# Altium schematic sheet Motor_Driver.SchDoc(Motor_Driver)
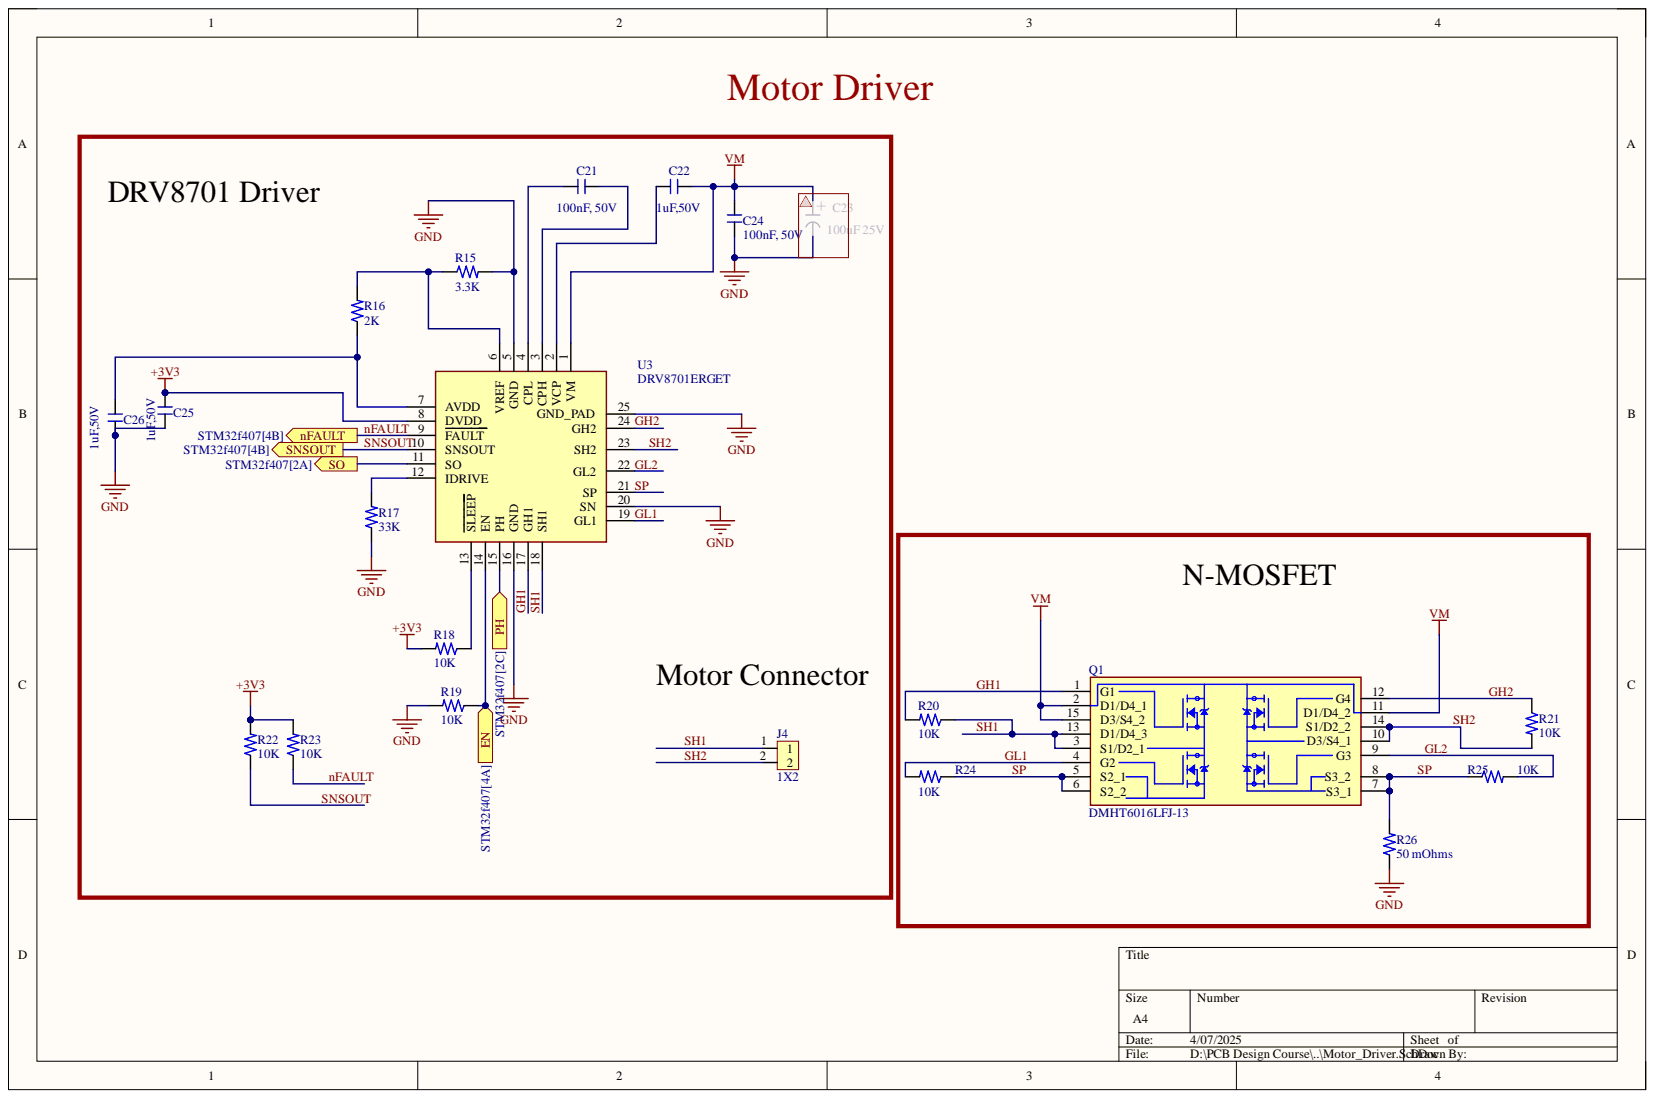
[diagram p0: Motor_Driver.SchDoc]

## Parts
C21  100nF, 50V  Voltage: 50V  [Capacitor_0805]
C22  1uF,50V  [Capacitor_0805]
C24  100nF, 50V  [Capacitor_0805]
C25  1uF,50V  [Capacitor_0805]
C26  1uF,50V  [Capacitor_0805]
J4  1X2  [1X2_2.53_JST]
Q1  DMHT6016LFJ-13  [V-DFN5045-12]
R15  3.3K  [Resistor_0603]
R16  2K  [Resistor_0603]
R17  33K  [Resistor_0805]
R18  10K  [Resistor_0603]
R19  10K  [Resistor_0603]
R20  10K  [Resistor_0603]
R21  10K  [Resistor_0603]
R22  10K  [Resistor_0603]
R23  10K  [Resistor_0603]
R24  10K  [Resistor_0603]
R25  10K  [Resistor_0603]
R26  50 mOhms  [Resistor_2512]
U3  DRV8701ERGET  [24_VQFN_4X4]

## Ports
EN, nFAULT, PH, SNSOUT, SO

## Nets
+3V3: C25.2 R18.2 R22.2 R23.2 U3.8(DVDD)
GND: C24.1 C25.1 C26.1 R15.2 R17.1 R19.2 R26.1 U3.5(GND) U3.16(GND) U3.20(SN) U3.25(GND_PAD)
VM: C22.2 C24.2 Q1.2(D1/D4_1) Q1.11(D1/D4_2) Q1.15(D3/S4_2) U3.1(VM)
EN: R19.1 U3.14(EN) ; port EN
GH1: Q1.1(G1) R20.2 U3.17(GH1)
GH2: Q1.12(G4) R21.1 U3.24(GH2)
GL1: Q1.4(G2) R24.2 U3.19(GL1)
GL2: Q1.9(G3) R25.2 U3.22(GL2)
nFAULT: R23.1 U3.9(FAULT) ; port nFAULT
PH: U3.15(PH) ; port PH
SH1: J4.1 Q1.3(S1/D2_1) Q1.13(D1/D4_3) R20.1 U3.18(SH1)
SH2: J4.2 Q1.10(D3/S4_1) Q1.14(S1/D2_2) R21.2 U3.23(SH2)
SNSOUT: R22.1 U3.10(SNSOUT) ; port SNSOUT
SO: U3.11(SO) ; port SO
SP: Q1.5(S2_1) Q1.6(S2_2) Q1.7(S3_1) Q1.8(S3_2) R24.1 R25.1 R26.2 U3.21(SP)
NetC21_1: C21.1 U3.4(CPL)
NetC21_2: C21.2 U3.3(CPH)
NetC22_1: C22.1 U3.2(VCP)
NetC26_2: C26.2 R16.1 U3.7(AVDD)
NetR15_1: R15.1 R16.2 U3.6(VREF)
NetR17_2: R17.2 U3.12(IDRIVE)
NetR18_1: R18.1 U3.13(SLEEP)

## Library data of the parts
C21 C24 = Cap_100nF_0805: Multilayer Ceramic Capacitor, 0.1 uF, 50 V, ï¿½ 10%, X7R, 0805 [2012 Metric]; Manufacturer: Samsung; Manufacturer Part Number: CL21B104KBCNNNC; Case Code (Imperial): 0805; Case Code (Metric): 2012; Case/Package: 0805; Category: Ceramic Capacitors; Component Kind: Standard; ComponentLink1Description: https://datasheet.ciiva.com/25710/cl21b104kbcnnnc-25710182.pdf?src-supplier=Digikey; ComponentLink1URL: https://datasheet.ciiva.com/25710/cl21b104kbcnnnc-25710182.pdf?src-supplier=Digikey; ComponentLink2Description: https://datasheet.ciiva.com/29199/454152-da-01-en-vs-kondensator-0805-29199814.pdf?src-supplier=Conrad; ComponentLink2URL: https://datasheet.ciiva.com/29199/454152-da-01-en-vs-kondensator-0805-29199814.pdf?src-supplier=Conrad; Construction: Flat; Depth: 1.25mm; Dielectric: X7R; Height: 0.85mm; Lead Free: 5697LeadFree; Length: 2mm; Material: Ceramic; Max Operating Temperature: 125°C; Min Operating Temperature: -55°C; Mounting Technology: Surface Mount; Packaging: Tape and Reel; Pricing: 1=0,08, 10=0,012, 100=0,0104, 1000=0,008 (USD); Radiation Hardening: No; RoHS: Yes; RoHS Compliant: Yes; Series: CL; Stock: 674609; Supplier: Digikey; Supplier Part Number: 1276-1003-1-ND; Thickness: 0.037inch; Tolerance: 10%; Value: 100nF; Voltage Rating: 50V; Voltage Rating (DC): 50V; Width: 0.049inch; Library Name: Schematics_Library_Hanif.SchLib
  C24: Voltage: 50V
C22 C25 C26 = Cap_1uF_0805: 0805 1 uF 50 V ±5 % Tolerance X7R Surface Mount Multilayer Ceramic Capacitor; Manufacturer: Yageo; Manufacturer Part Number: CC0805JKX7R9BB105; Dielectric: 1511DielectricType; Material: 4241ConductorMaterial; Category: Ceramic Capacitors; Component Kind: Standard; ComponentLink1Description: https://datasheet.octopart.com/CC0805JKX7R9BB105-Yageo-datasheet-137700082.pdf?src-supplier=Future+Electronics; ComponentLink1URL: https://datasheet.octopart.com/CC0805JKX7R9BB105-Yageo-datasheet-137700082.pdf?src-supplier=Future+Electronics; ComponentLink2Description: http://www.yageo.com/documents/recent/UPY-GPHC_X7R_6.3V-to-50V_18.pdf?src-supplier=Digikey; ComponentLink2URL: http://www.yageo.com/documents/recent/UPY-GPHC_X7R_6.3V-to-50V_18.pdf?src-supplier=Digikey; ComponentLink3Description: https://datasheet.octopart.com/CC0805JKX7R9BB105-Yageo-datasheet-151948686.pdf?src-supplier=Farnell; ComponentLink3URL: https://datasheet.octopart.com/CC0805JKX7R9BB105-Yageo-datasheet-151948686.pdf?src-supplier=Farnell; Halogen Free: 5694HalogenFree; Height: 1.45mm; Lead Free: 5697LeadFree; Pricing: 1=0,29, 10=0,168, 100=0,1077, 1000=0,07673 (USD); RoHS: Yes; Stock: 30160; Supplier: Digikey; Supplier Part Number: 311-3498-1-ND; Voltage Rating (DC): 50V; Library Name: Schematics_Library_Hanif.SchLib
J4 = CON_JST_1X2_2.54: Conn Shrouded Header HDR 2 POS 2.5mm Solder ST Thru-Hole Box; Manufacturer: JST; Manufacturer Part Number: B2B-XH-2(LF)(SN); Number of Contacts: 2NUMBER; Number of Positions: 2; Voltage Rating: 250V; Category: Headers and Wire Housings; Component Kind: Standard; Connector Type: Header; Contact Finish - Mating: TIN; Contact Material: Brass; Contact Shape: Square; Contact Type: Male Pin; Fastening Type: Detent; Flammability Rating: UL94 V-0; Insulation Color: Natural; Insulation Material: Nylon; Max Operating Temperature: 85°C; Min Operating Temperature: -25°C; Mounting Technology: Through Hole; Number of Rows: 1; Packaging: Bulk; Pricing: 1=0,2, 10=0,158, 50=0,131, 100=0,117, 1000=0,072, 10000=0,07 (USD); RoHS: Yes; Series: XH; Stock: 1704; Supplier: Mouser; Supplier Part Number: 306-B2B-XH-2LFSN; Termination: Press-Fit; Library Name: Schematics_Library_Hanif.SchLib
Q1 = IC_MOSFET_ARRAY_4N: Transistor MOSFET Array Quad N-CH 60V 14.8A 12-Pin VDFN T/R; Manufacturer: Diodes; FET Type: 4 N-Channel; Input Capacitance: 864pF; Rds On Max: 22mR; Category: MOSFETs; Component Kind: Standard; ComponentLink1Description: https://www.diodes.com/assets/Datasheets/DMHT6016LFJ.pdf?src-supplier=Digikey; ComponentLink1URL: https://www.diodes.com/assets/Datasheets/DMHT6016LFJ.pdf?src-supplier=Digikey; Continuous Drain Current (ID): 14.8A; Manufacturer Part Number: DMHT6016LFJ-13; Max Operating Temperature: 150°C; Min Operating Temperature: -55°C; Mounting Technology: Surface Mount; Packaging: Cut Tape; Pricing: 1=2,31, 10=1,485, 100=1,0129, 500=0,81076, 1000=0,74579 (USD); RoHS: Yes; Series: Automotive, AEC-Q101; Stock: 8228; Supplier: Digikey; Supplier Part Number: DMHT6016LFJ-13DICT-ND; Library Name: Schematics_Library_Hanif.SchLib
R15 = R_3.3K_0603: Res Thick Film 0603 3.3K Ohm 1% 0.1W(1/10W) ±100ppm/C Pad SMD T/R; Manufacturer: Walsin Technologies; Manufacturer Part Number: WR06X3301FTL; Power Rating: 100mW; Temperature Coefficient: 100ppm/°C; Value: 3.3kR; Voltage Rating: 75V; Case Code (Imperial): 0603; Case Code (Metric): 1608; Case/Package: 0603; Category: Chip SMD Resistors; Component Kind: Standard; ComponentLink1Description: http://www.farnell.com/datasheets/1960319.pdf?src-supplier=Farnell; ComponentLink1URL: http://www.farnell.com/datasheets/1960319.pdf?src-supplier=Farnell; ComponentLink2Description: https://datasheet.octopart.com/WR06X3301FTL-Walsin-Technologies-datasheet-48038251.pdf?src-supplier=Element14; ComponentLink2URL: https://datasheet.octopart.com/WR06X3301FTL-Walsin-Technologies-datasheet-48038251.pdf?src-supplier=Element14; ComponentLink3Description: http://www.farnell.com/datasheets/1960319.pdf?src-supplier=Element14; ComponentLink3URL: http://www.farnell.com/datasheets/1960319.pdf?src-supplier=Element14; ComponentLink4Description: https://datasheet.octopart.com/WR06X3301FTL-Walsin-Technologies-datasheet-32780790.pdf?src-supplier=Future+Electronics; ComponentLink4URL: https://datasheet.octopart.com/WR06X3301FTL-Walsin-Technologies-datasheet-32780790.pdf?src-supplier=Future+Electronics; Contact Material: 154ConductorMaterial; Lead Free: 5697LeadFree; Mounting Technology: Surface Mount; Packaging: Tape and Reel; Pricing: 1=0,1, 10=0,015, 50=0,0086, 100=0,0068, 500=0,00418, 1000=0,00345 (USD); Reach SVHC Compliant: Unknown; Resistance Tolerance: 1%; Resistor Type: Thick Film; RoHS: Yes; RoHS Compliant: Yes; Stock: 24599; Supplier: Digikey; Supplier Part Number: 1292-WR06X3301FTLCT-ND; Voltage Rating (DC): 75V; Library Name: Schematics_Library_Hanif.SchLib
R16 = R_2k_0603: Res Thin Film 0603 2K Ohm 1% 0.125W(1/8W) ±100ppm/C Sulfur Resistant Pad SMD T/R; Manufacturer: Stackpole Electronics; Manufacturer Part Number: RNCP0603FTD2K00; Max Power Dissipation: 125mW; Temperature Coefficient: 100ppm/°C; Value: 2kR; Voltage Rating: 150V; Case Code (Imperial): 0603; Case Code (Metric): 1608; Case/Package: 0603; Category: Chip SMD Resistors; Component Kind: Standard; ComponentLink1Description: https://www.seielect.com/catalog/SEI-Packaging.pdf?src-supplier=Digikey; ComponentLink1URL: https://www.seielect.com/catalog/SEI-Packaging.pdf?src-supplier=Digikey; Features: Moisture Resistant,Anti-Sulfur; Height: 0.02inch; Lead Free: Lead Free; Length: 0.059inch; Max Operating Temperature: 155°C; Min Operating Temperature: -55°C; Mounting Technology: Surface Mount; Number of Terminations: 2; Packaging: Cut Tape; Pricing: 1=0,1, 10=0,012, 50=0,0108, 100=0,0106, 500=0,00848 (USD); RoHS: Yes; RoHS Compliant: Yes; Series: RNCP; Stock: 64735; Supplier: Digikey; Supplier Part Number: RNCP0603FTD2K00CT-ND; Tolerance: 1%; Width: 0.031inch; Library Name: Schematics_Library_Hanif.SchLib
R17 = R_33K_0805: Res Thick Film 0805 33K Ohm 1% 0.125W(1/8W) ±100ppm/C Pad SMD T/R; Manufacturer: TE Connectivity; Manufacturer Part Number: CRG0805F33K; Max Power Dissipation: 125mW; Number of Resistors: 1; Operating Supply Voltage: 150V; Temperature Coefficient: 100ppm/°C; Value: 33kR; Case Code (Imperial): 0805; Case Code (Metric): 2012; Case/Package: 0805; Category: Chip SMD Resistors; Component Kind: Standard; ComponentLink1Description: https://datasheet.ciiva.com/2530/eng-cd-1623197-ba-2530138.pdf?src-supplier=Digikey; ComponentLink1URL: https://datasheet.ciiva.com/2530/eng-cd-1623197-ba-2530138.pdf?src-supplier=Digikey; ComponentLink2Description: https://datasheet.ciiva.com/11818/0900766b800a696e-11818279.pdf?src-supplier=Rs; ComponentLink2URL: https://datasheet.ciiva.com/11818/0900766b800a696e-11818279.pdf?src-supplier=Rs; ComponentLink3Description: https://datasheet.ciiva.com/5597/eng-ds-1773204-g-5597309.pdf?src-supplier=Mouser; ComponentLink3URL: https://datasheet.ciiva.com/5597/eng-ds-1773204-g-5597309.pdf?src-supplier=Mouser; ComponentLink4Description: https://datasheet.ciiva.com/16772/70063020-16772846.pdf?src-supplier=Allied+Electronics; ComponentLink4URL: https://datasheet.ciiva.com/16772/70063020-16772846.pdf?src-supplier=Allied+Electronics; Depth: 1.25mm; ELV: Compliant; Height: 0.55mm; Length: 2mm; Max Operating Temperature: 125°C; Military Standard: Not; Min Operating Temperature: -55°C; Mounting Technology: Surface Mount; Number of Terminations: 2; Packaging: Tape and Reel; Power Rating: 125mW; Pricing: 1=0,1, 10=0,037, 100=0,019, 1000=0,01105, 2500=0,00928 (USD); Resistor Type: Thick Film; RoHS: Yes; RoHS Compliant: Yes; Series: CRG; Stock: 31419; Supplier: Digikey; Supplier Part Number: A126362CT-ND; Technology: THICK FILM; Termination: Solder; Tolerance: 1%; Voltage Rating: 150V; Voltage Rating (DC): 150V; Width: 1.25mm; Library Name: Schematics_Library_Hanif.SchLib
R18-R25 = R_10K_0603: SMD Chip Resistor, 10 kOhm, ï¿½ 1%, 100 mW, 0603 [1608 Metric], Thick Film, General Purpose; Manufacturer: Yageo; Manufacturer Part Number: RC0603FR-0710KL; Max Power Dissipation: 100mW; Temperature Coefficient: 100ppm/°C; Value: 10kR; Voltage Rating: 75V; Voltage Rating (DC): 50V; Case Code (Imperial): 0603; Case Code (Metric): 1608; Case/Package: 0603; Category: Chip SMD Resistors; Component Kind: Standard; ComponentLink1Description: https://datasheet.ciiva.com/7660/38590-7660789.pdf?src-supplier=Element14; ComponentLink1URL: https://datasheet.ciiva.com/7660/38590-7660789.pdf?src-supplier=Element14; ComponentLink2Description: https://datasheet.ciiva.com/7983/443971-7983596.pdf?src-supplier=Element14; ComponentLink2URL: https://datasheet.ciiva.com/7983/443971-7983596.pdf?src-supplier=Element14; ComponentLink3Description: https://datasheet.ciiva.com/22747/443971-22747519.pdf?src-supplier=Element14; ComponentLink3URL: https://datasheet.ciiva.com/22747/443971-22747519.pdf?src-supplier=Element14; ComponentLink4Description: https://datasheet.ciiva.com/46440/1868787-46440891.pdf?src-supplier=Element14; ComponentLink4URL: https://datasheet.ciiva.com/46440/1868787-46440891.pdf?src-supplier=Element14; ComponentLink5Description: https://datasheet.ciiva.com/7746/1738695-7746239.pdf?src-supplier=Element14; ComponentLink5URL: https://datasheet.ciiva.com/7746/1738695-7746239.pdf?src-supplier=Element14; ComponentLink6Description: https://datasheet.ciiva.com/15189/getdatasheetpartid-409289-15189591.pdf?src-supplier=Verical; ComponentLink6URL: https://datasheet.ciiva.com/15189/getdatasheetpartid-409289-15189591.pdf?src-supplier=Verical; Construction: Rectangular; Depth: 0.8mm; Features: Moisture Resistant; Height: 0.45mm; Lead Free: 5697LeadFree; Lead Pitch: 0.3mm; Length: 1.6mm; Max Operating Temperature: 155°C; Military Standard: Not; Min Operating Temperature: -55°C; Number of Terminations: 2; Packaging: Tape and Reel; Pins: 2; Power Rating: 100mW; Pricing: 1=0,1, 10=0,009, 100=0,0071, 1000=0,00612 (USD); Reach SVHC Compliant: No; Resistance Tolerance: 1%; Resistor Type: Thick Film; RoHS: Yes; RoHS Compliant: Yes; Series: RC; Stock: 2808060; Supplier: Digikey; Supplier Part Number: 311-10.0KHRCT-ND; Termination: SMD/SMT; Tolerance: 1%; Weight: 7.1E-05oz; Width: 0.8mm; Library Name: Schematics_Library_Hanif.SchLib
R26 = R_50mOhms_2012: Res Current Sense Metal Film 2512 50m Ohm 1% 2W ±100ppm/°C Molded Emboss T/R; Manufacturer: Yageo; Manufacturer Part Number: PA2512FKF7W0R05E; Value: 50mR; Case Code (Imperial): 2512; Case Code (Metric): 6432; Case/Package: 2512; Category: Chip SMD Resistors; Component Kind: Standard; Features: Moisture Resistant,Automotive AEC-Q200; Height: 880um; Max Operating Temperature: 170°C; Max Power Dissipation: 2W; Min Operating Temperature: -55°C; Number of Terminations: 2; Packaging: Cut Tape; Power Rating: 2W; Pricing: 1=0,8, 10=0,521, 25=0,444, 50=0,3958, 100=0,3549, 250=0,31004, 500=0,28194, 1000=0,25807 (USD); Ratings: AEC-Q200; RoHS: Yes; RoHS Compliant: Yes; Series: PA_E; Stock: 10433; Supplier: Digikey; Supplier Part Number: YAG6100CT-ND; Temperature Coefficient: 100ppm/°C; Tolerance: 1%; Library Name: Schematics_Library_Hanif.SchLib
U3 = IC_Motor_DriverDRV8701ERGET: 4.5V to 60V, 2A Step-Down Power Module in QFN Package 43-B3QFN -40 to 105; Manufacturer: Texas Instruments; Category: Integrated Circuits (ICs); Component Kind: Standard; ComponentLink1Description: http://www.ti.com/lit/ds/symlink/drv8701.pdf?src-supplier=Digikey; ComponentLink1URL: http://www.ti.com/lit/ds/symlink/drv8701.pdf?src-supplier=Digikey; ComponentLink2Description: http://www.ti.com/general/docs/lit/getliterature.tsp?genericPartNumber=DRV8701&&fileType=pdf&src-supplier=Avnet; ComponentLink2URL: http://www.ti.com/general/docs/lit/getliterature.tsp?genericPartNumber=DRV8701&&fileType=pdf&src-supplier=Avnet; Height: 1mm; Lead Free: 5697LeadFree; Length: 4mm; Manufacturer Part Number: DRV8701ERGET; Pins: 24NUMBER; Pricing: 1=2,56, 10=1,91, 50=1,75, 100=1,57, 1000=1,35, 10000=1,28 (USD); RoHS: Yes; Stock: 1460; Supplier: Mouser; Supplier Part Number: 595-DRV8701ERGET; Thickness: 880um; Width: 4mm; Library Name: Schematics_Library_Hanif.SchLib
